# Altium schematic sheet DAC.SchDoc
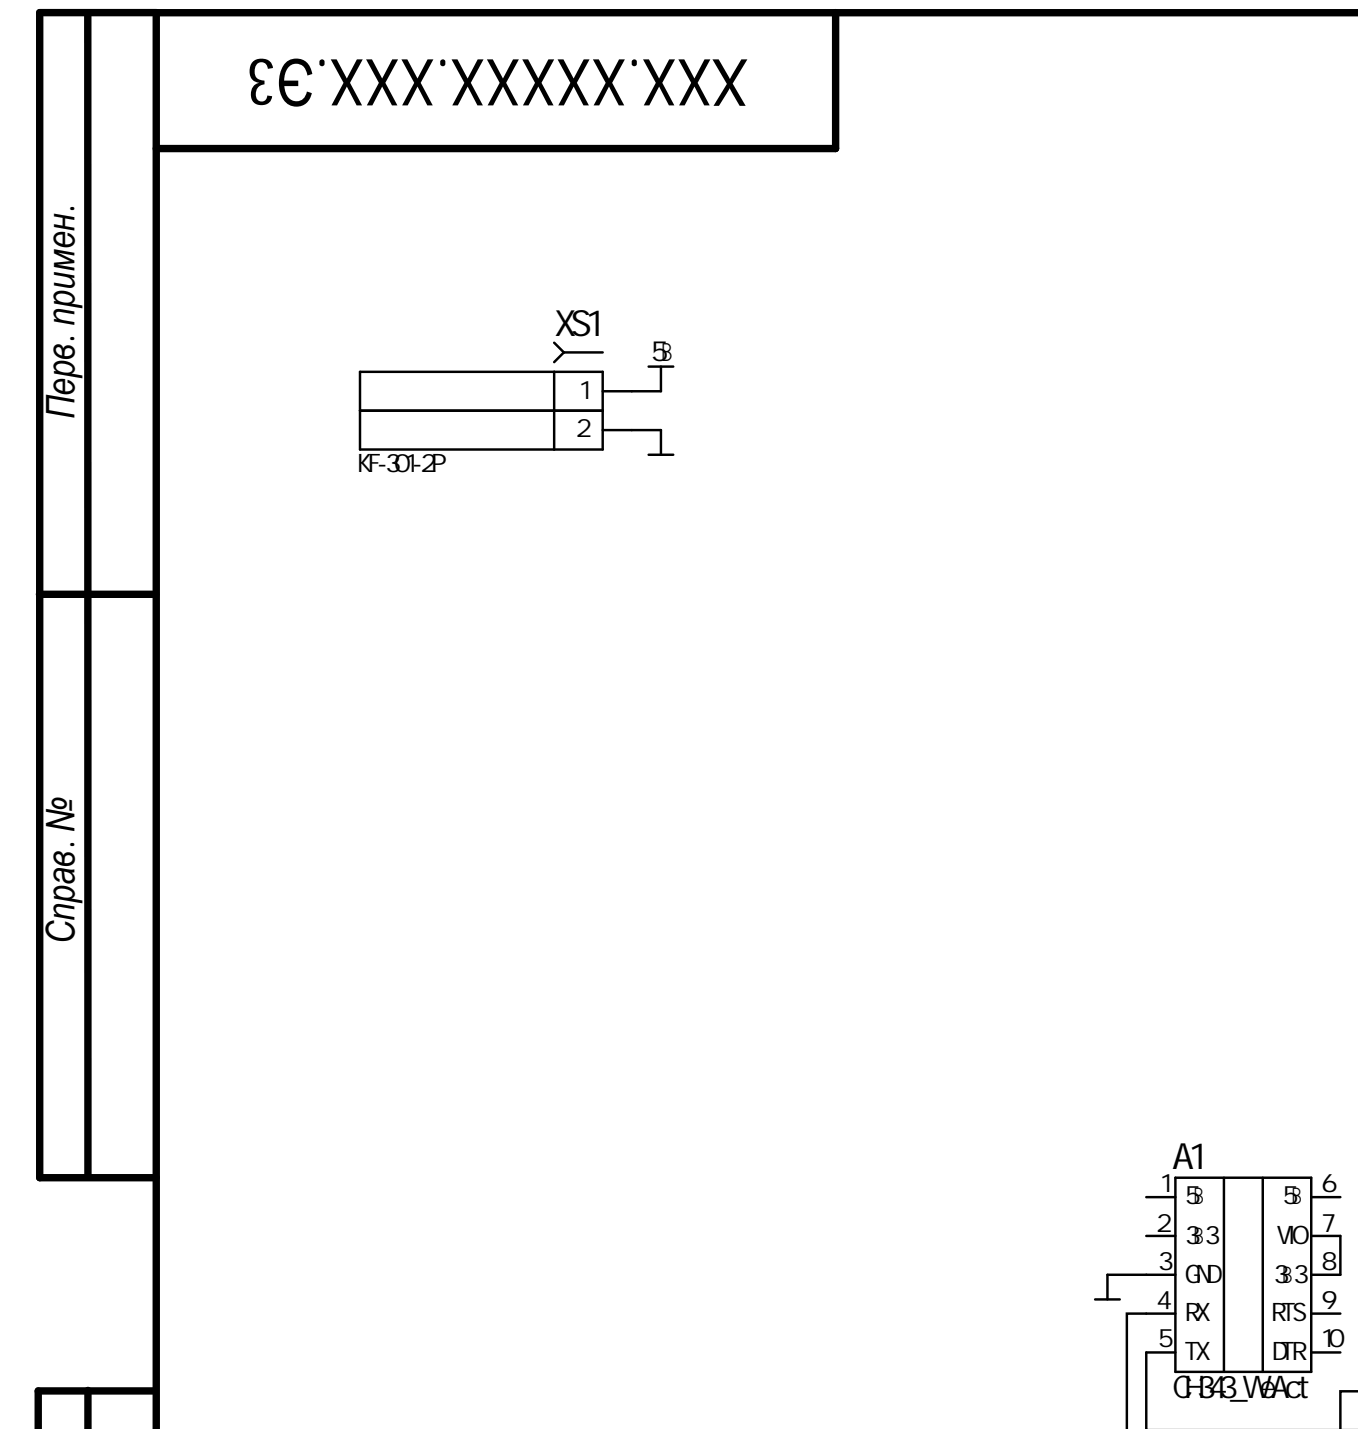
[diagram p0: DAC.SchDoc, top left]
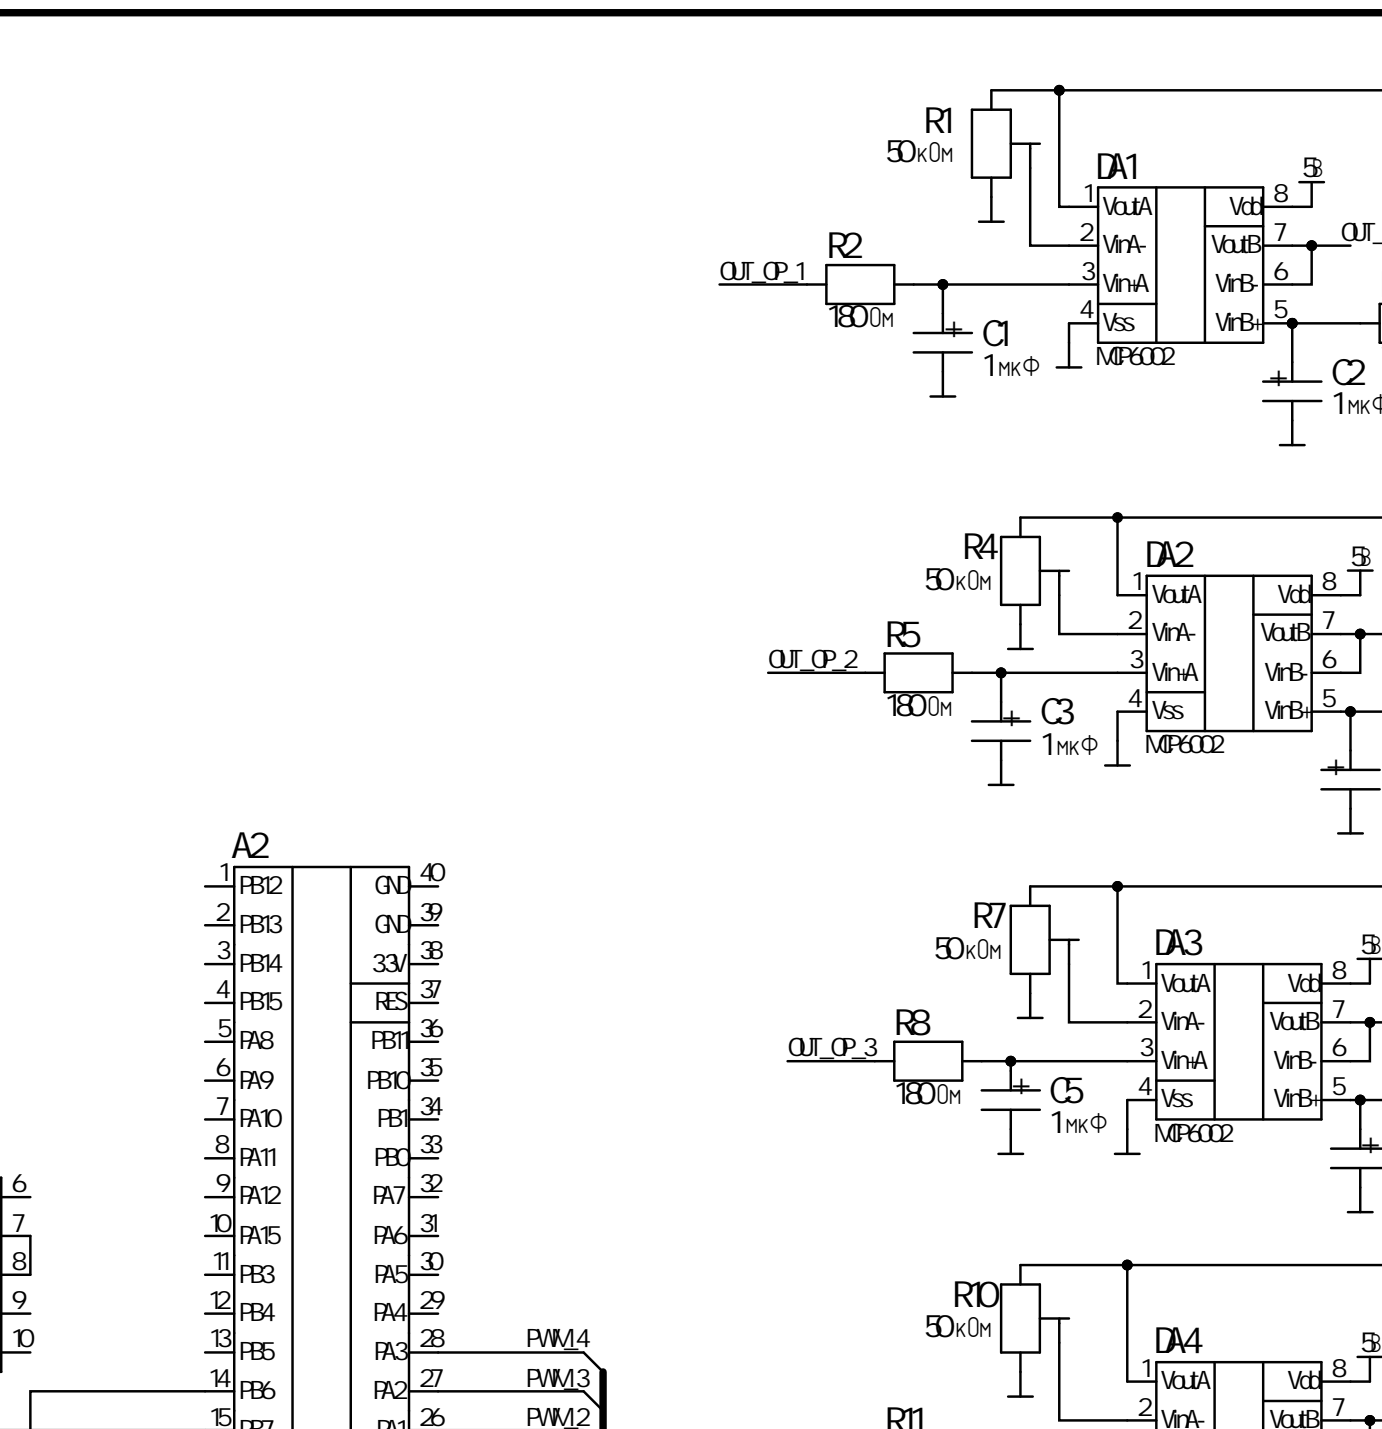
[diagram p1: DAC.SchDoc, top centre]
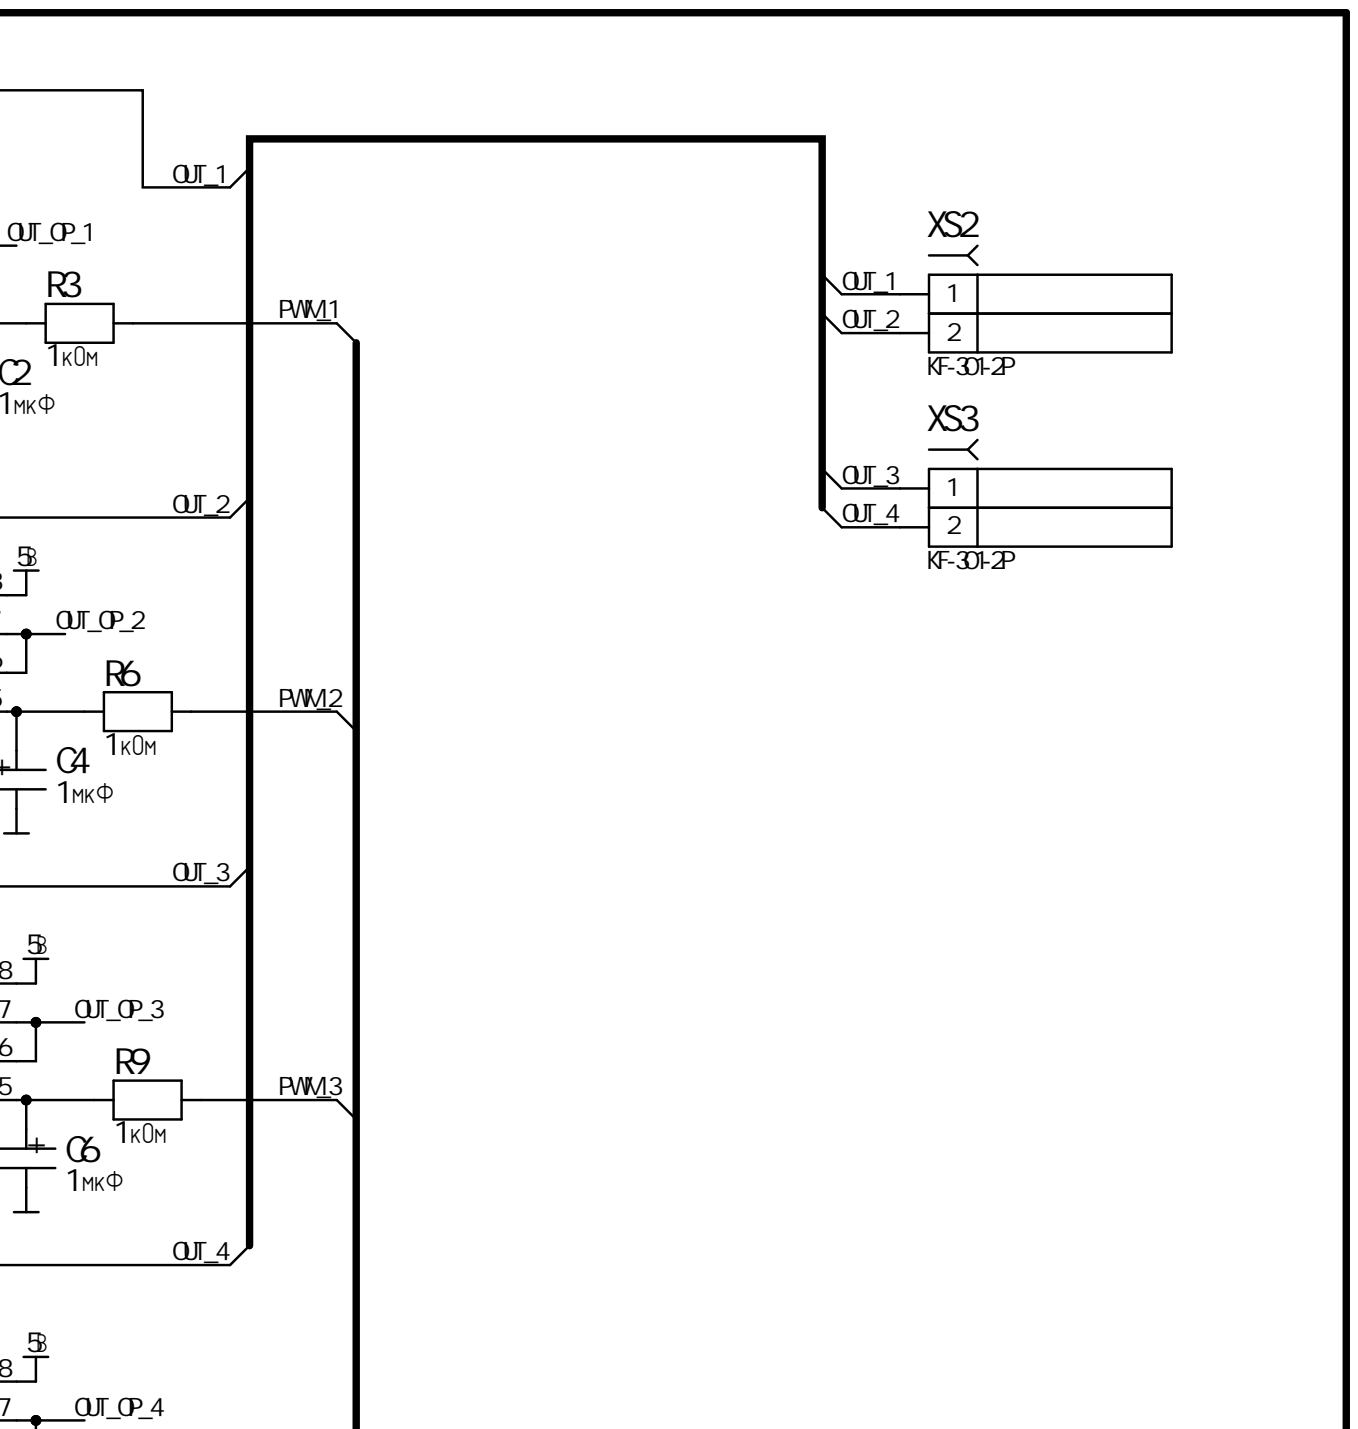
[diagram p2: DAC.SchDoc, top right]
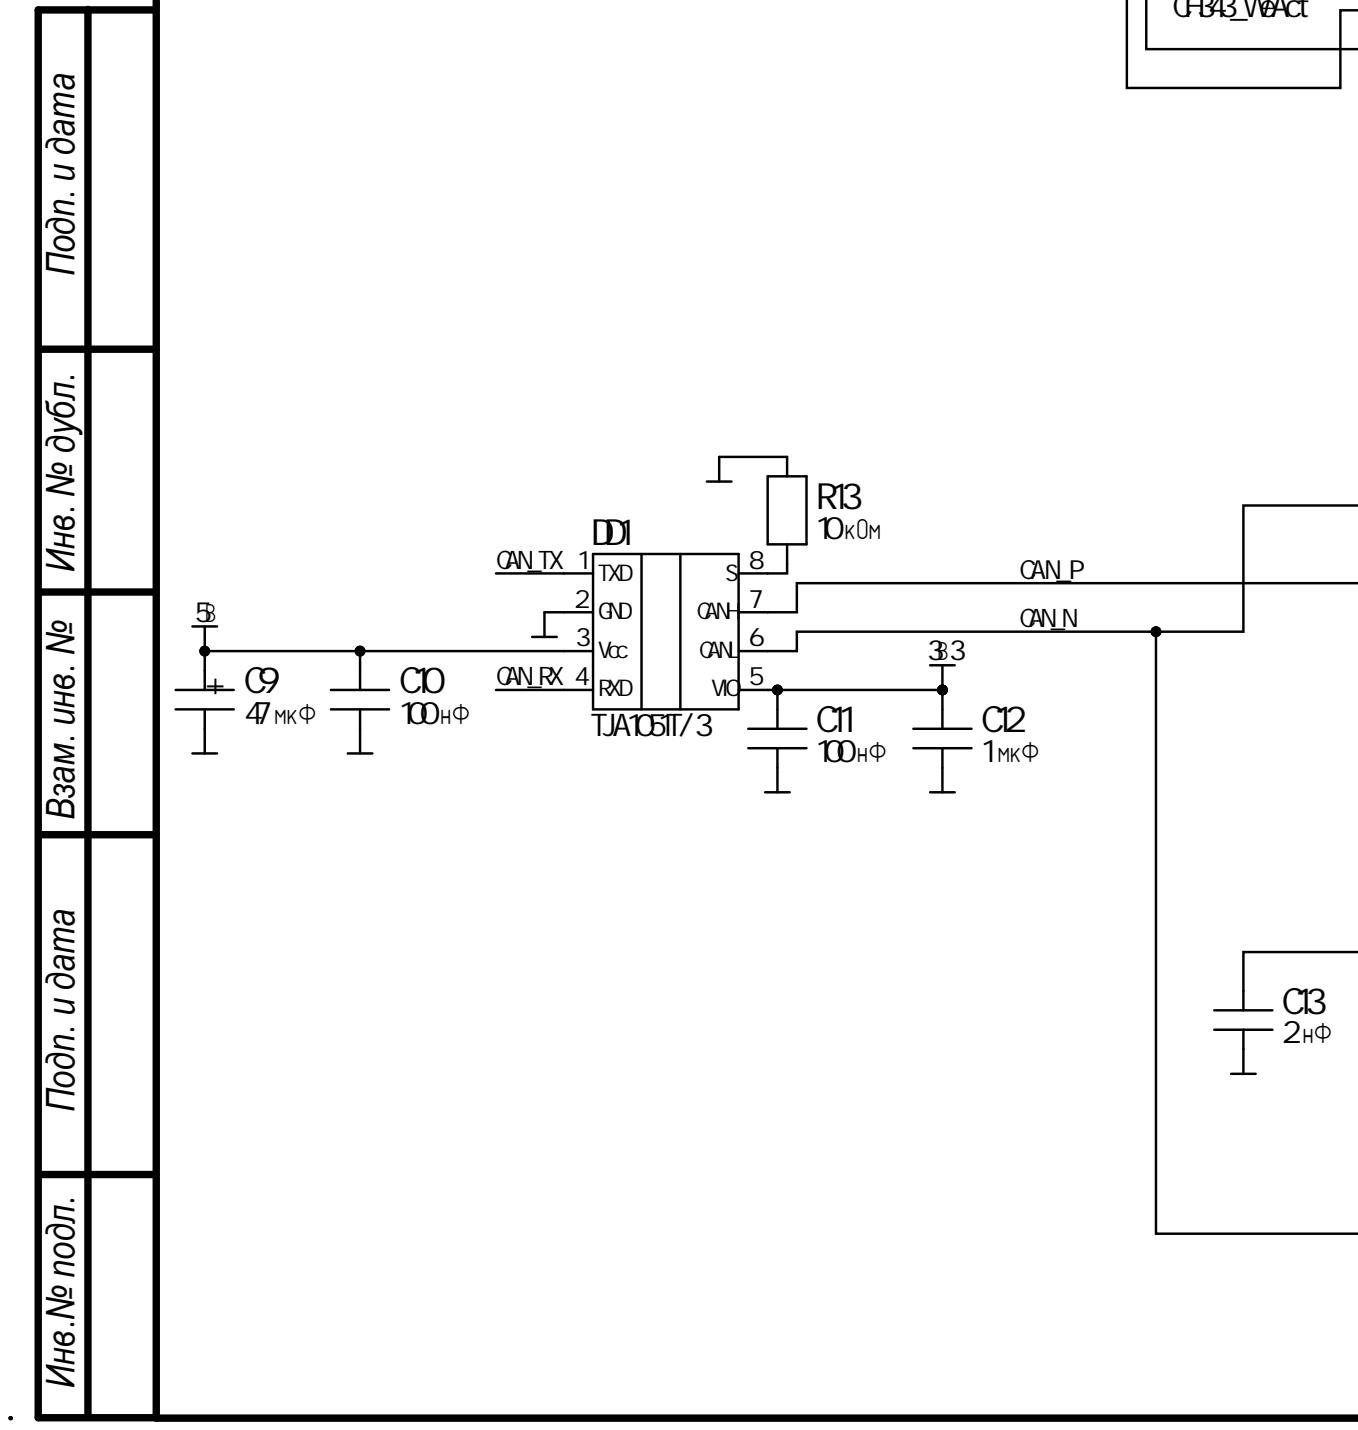
[diagram p3: DAC.SchDoc, bottom left]
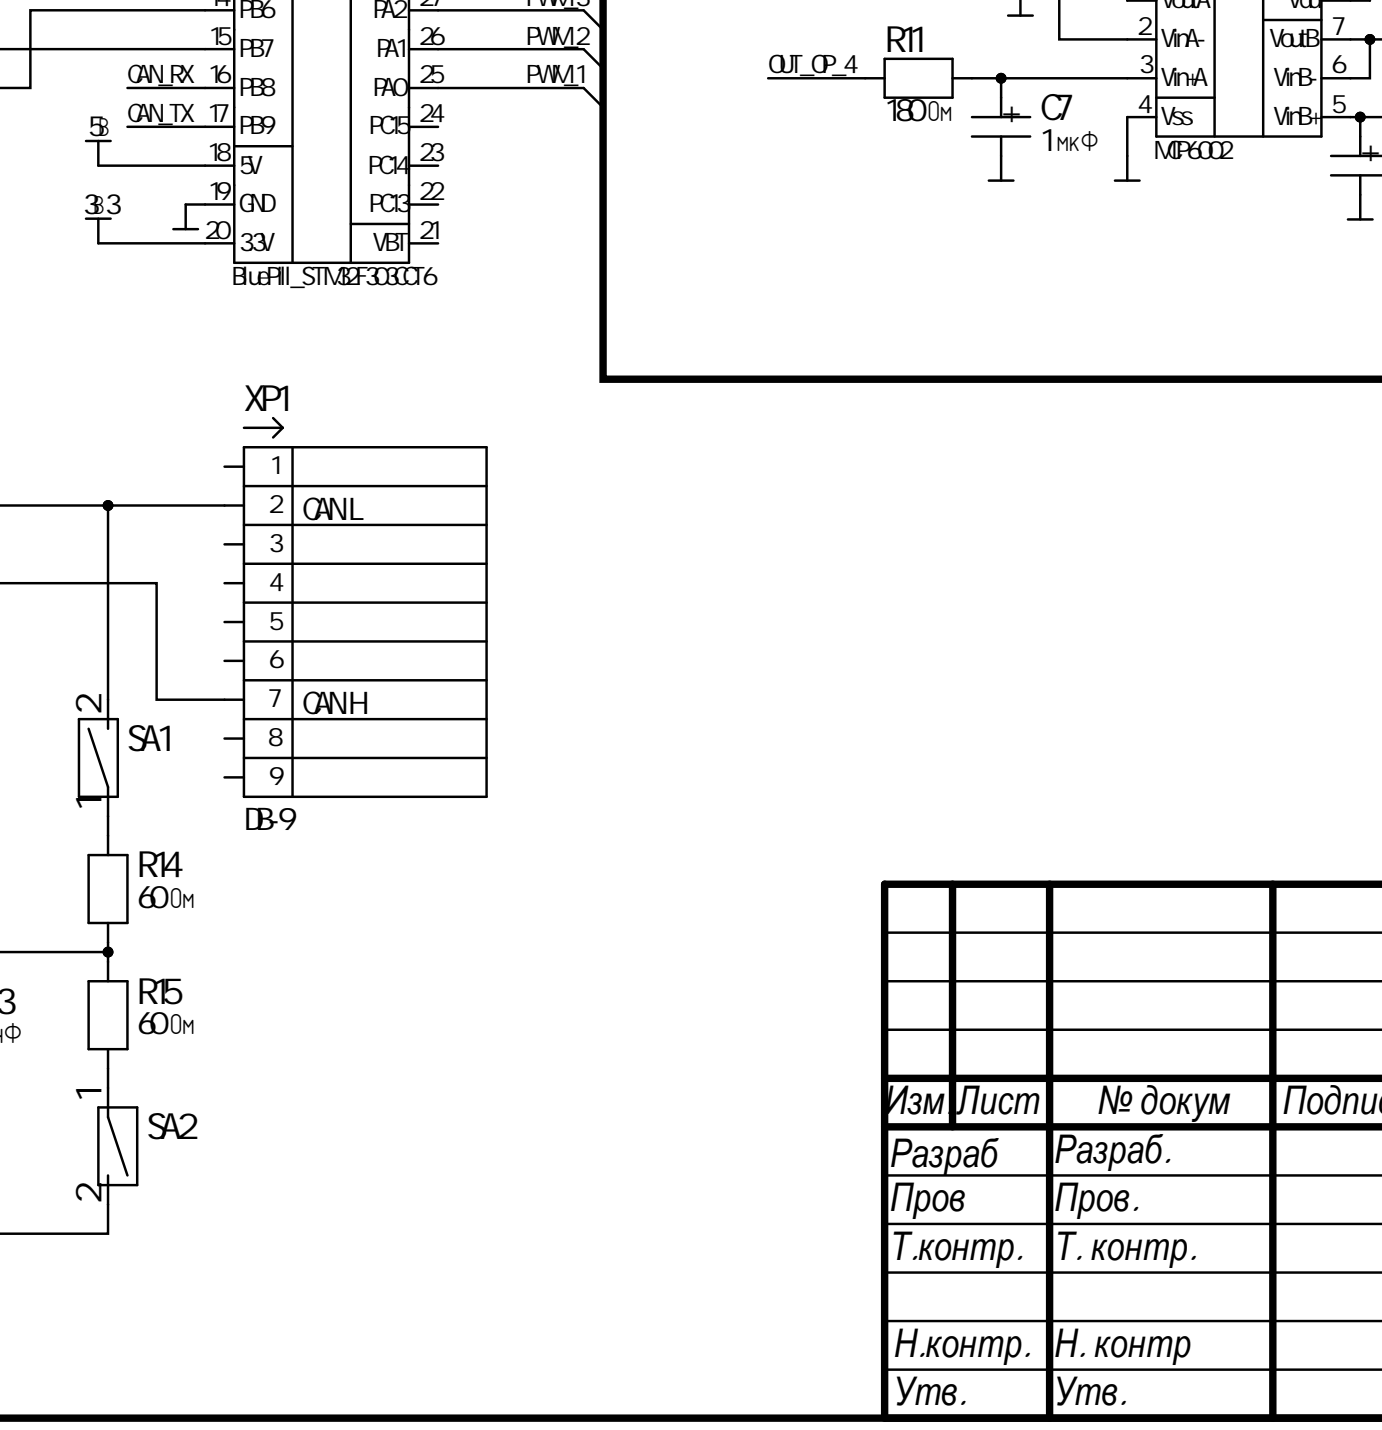
[diagram p4: DAC.SchDoc, bottom centre]
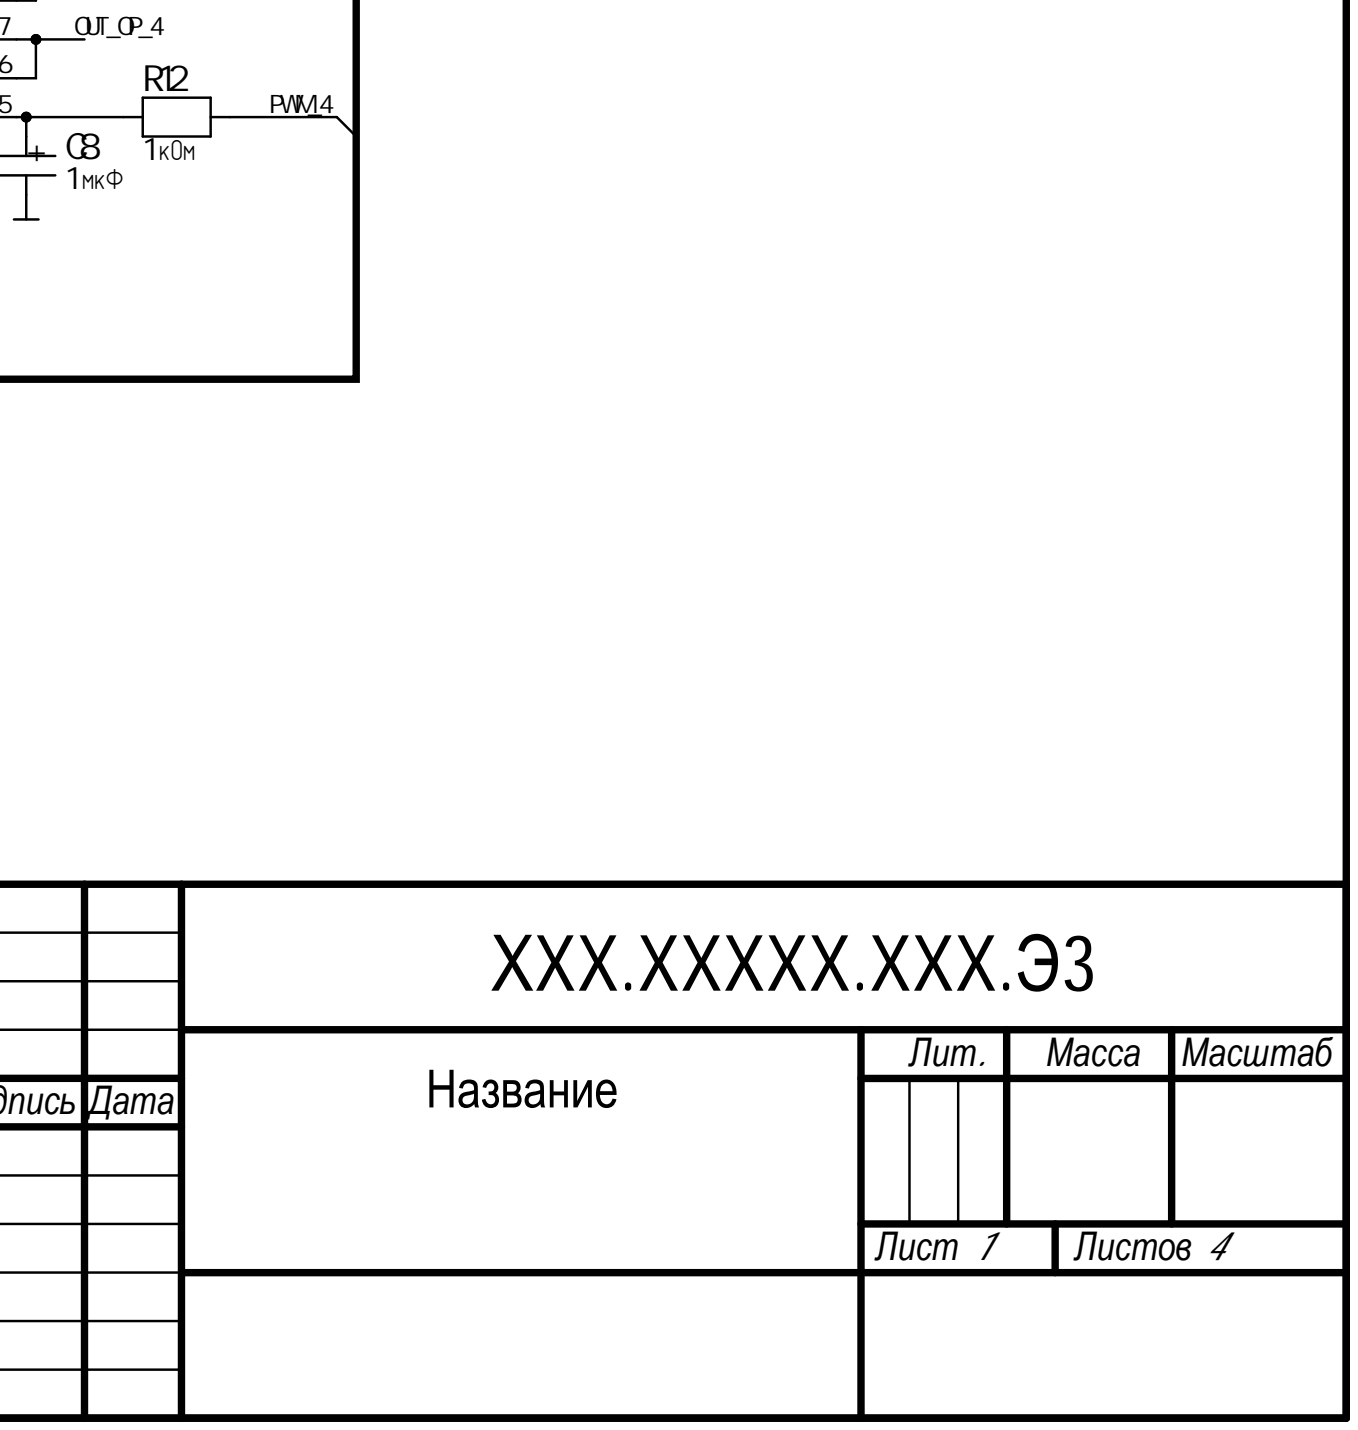
[diagram p5: DAC.SchDoc, bottom right]

## Parts
A1  CH343_WeAct
A2  BluePill_STM32F303CCT6
C1  1 мкФ  [capr- 2.5D6.5H12]
C2  1 мкФ  [capr- 2.5D6.5H12]
C3  1 мкФ  [capr- 2.5D6.5H12]
C4  1 мкФ  [capr- 2.5D6.5H12]
C5  1 мкФ  [capr- 2.5D6.5H12]
C6  1 мкФ  [capr- 2.5D6.5H12]
C7  1 мкФ  [capr- 2.5D6.5H12]
C8  1 мкФ  [capr- 2.5D6.5H12]
C9  47 мкФ  [Tant B]
C10  100 нФ  [CAP 0603 3D]
C11  100 нФ  [CAP 0603 3D]
C12  1 мкФ  [CAP 0603 3D]
C13  2 нФ  [CAP 0603 3D]
DA1  MCP6002  [SOIC-8 150mil]
DA2  MCP6002  [SOIC-8 150mil]
DA3  MCP6002  [SOIC-8 150mil]
DA4  MCP6002  [SOIC-8 150mil]
DD1  TJA1051T/3  [SOIC-8 150mil]
R1  50 кОм  [POT 3214 g]
R2  180 Ом  [RES 0.125  L 2.5]
R3  1 кОм  [RES 0.125  L 2.5]
R4  50 кОм  [POT 3266P]
R5  180 Ом  [RES 0.125  L 2.5]
R6  1 кОм  [RES 0.125  L 2.5]
R7  50 кОм  [POT 3266P]
R8  180 Ом  [RES 0.125  L 2.5]
R9  1 кОм  [RES 0.125  L 2.5]
R10  50 кОм  [POT 3266P]
R11  180 Ом  [RES 0.125  L 2.5]
R12  1 кОм  [RES 0.125  L 2.5]
R13  10 кОм  [RES 0.125  L 2.5]
R14  60 Ом  [RES 0.125  L 2.5]
R15  60 Ом  [RES 0.125  L 2.5]
SA1  SA x 1  [A6S1101(2,4)H]  (value not drawn)
SA2  SA x 1  [A6S1101(2,4)H]  (value not drawn)
XP1  DB-9  [KLS1-207-1*09]
XS1  KF-301-2P  [Degson_DG301-5.0-02P-1X]
XS2  KF-301-2P  [Degson_DG301-5.0-02P-1X]
XS3  KF-301-2P  [Degson_DG301-5.0-02P-1X]

## Nets
3В3: A2.20(3_.3_V) C11.1 C12.1 DD1.5(IO)
5В: A2.18(5V) C9.1 C10.1 DA1.8(dd) DA2.8(dd) DA3.8(dd) DA4.8(dd) DD1.3 XS1.1
GND: A1.3(G) A2.19(G) C1.1 C2.1 C3.1 C4.1 C5.1 C6.1 C7.1 C8.1 C9.2 C10.2 C11.2 C12.2 C13.2 DA1.4(V) DA2.4(V) DA3.4(V) DA4.4(V) DD1.2 R1.3 R4.3 R7.3 R10.3 R13.2 XS1.2
CAN_N: DD1.6(L) SA1.2 SA2.2 XP1.2
CAN_P: DD1.7(H) XP1.7
CAN_RX: A2.16(P) DD1.4(RX)
CAN_TX: A2.17(P) DD1.1(TX)
OUT_1: DA1.1(V) R1.1 XS2.1
OUT_2: DA2.1(V) R4.1 XS2.2
OUT_3: DA3.1(V) R7.1 XS3.1
OUT_4: DA4.1(V) R10.1 XS3.2
OUT_OP_1: DA1.6(-) DA1.7(outB) R2.1
OUT_OP_2: DA2.6(-) DA2.7(outB) R5.1
OUT_OP_3: DA3.6(-) DA3.7(outB) R8.1
OUT_OP_4: DA4.6(-) DA4.7(outB) R11.1
PWM_1: A2.25 R3.1
PWM_2: A2.26 R6.1
PWM_3: A2.27 R9.2
PWM_4: A2.28 R12.2
NetA1_4: A1.4(R) A2.14(P)
NetA1_5: A1.5(T) A2.15(P)
NetA1_7: A1.7(IO) A1.8(3_В3)
NetC1_2: C1.2 DA1.3(V) R2.2
NetC2_2: C2.2 DA1.5(+) R3.2
NetC3_2: C3.2 DA2.3(V) R5.2
NetC4_2: C4.2 DA2.5(+) R6.2
NetC5_2: C5.2 DA3.3(V) R8.2
NetC6_2: C6.2 DA3.5(+) R9.1
NetC7_2: C7.2 DA4.3(V) R11.2
NetC8_2: C8.2 DA4.5(+) R12.1
NetC13_1: C13.1 R14.1 R15.2
NetDA1_2: DA1.2(V) R1.2
NetDA2_2: DA2.2(V) R4.2
NetDA3_2: DA3.2(V) R7.2
NetDA4_2: DA4.2(V) R10.2
NetDD1_8: DD1.8(S) R13.1
NetR14_2: R14.2 SA1.1
NetR15_1: R15.1 SA2.1

Not connected: A1 1(5_В) 2(3_В3) 6(5_В) 9(S) 10(R); A2 1(P) 2(P) 3(P) 4(P) 5(PA) 6(PA) 7(PA) 8(PA) 9(PA) 10(PA) 11(P) 12(P) 13(P) 21(T) 22(P) 23(P) 24(P) 29 30 31 32 33 34 35 36 37(ES) 38(3_.3_V) 39(D) 40(D); XP1 1 3 4 5 6 8 9

## Library data of the parts
A2 = BluePill: Component Kind: Standard; Library Name: LB1.IntLib
C1-C8 = C Al R: Конденсатор алюминиевый радиальный; Component Kind: Standard; Library Name: RLC V6.4_BVI_under.IntLib
C9 = C T: Конденсатор тантал; Component Kind: Standard; Library Name: RLC V6.4_BVI_under.IntLib
C10-C13 = C: Конденсатор керамика; Component Kind: Standard; Library Name: RLC V6.4_BVI_under.IntLib
DA1-DA4 = MCP602: Component Kind: Standard; Library Name: LB1.IntLib
R1 R4 R7 R10 = R POT: Потенциометр BOURNS; Component Kind: Standard; Library Name: RLC V6.4_BVI_under.IntLib
R2 R3 R5 R6 R8 R9 R11-R15 = R: Резисторы плёночные (SMD; МЛТ; С2-33Н; MP9xxx); Component Kind: Standard; Library Name: RLC V6.4_BVI_under.IntLib
SA1 SA2 = 2_ SA x 1: DIP  SMD  переключатели; Component Kind: Standard; Library Name: Switches V3.3_BVI.IntLib
XP1 = Штырь 1* 9  (1): Разъём штыревой на плату 2.54мм 1х9 pin; Component Kind: Standard; Library Name: Connectors V8.2_BVI.IntLib
A1 = CH343_WeAct: Component Kind: Standard; Library Name: LB1.IntLib
DD1 = TJA1051T/3: Component Kind: Standard; Library Name: LB1.IntLib
XS1-XS3 = KF-301-2P: Component Kind: Standard; Library Name: LB1.IntLib
